# Altium schematic sheet Sheet4.SchDoc(Sheet4)
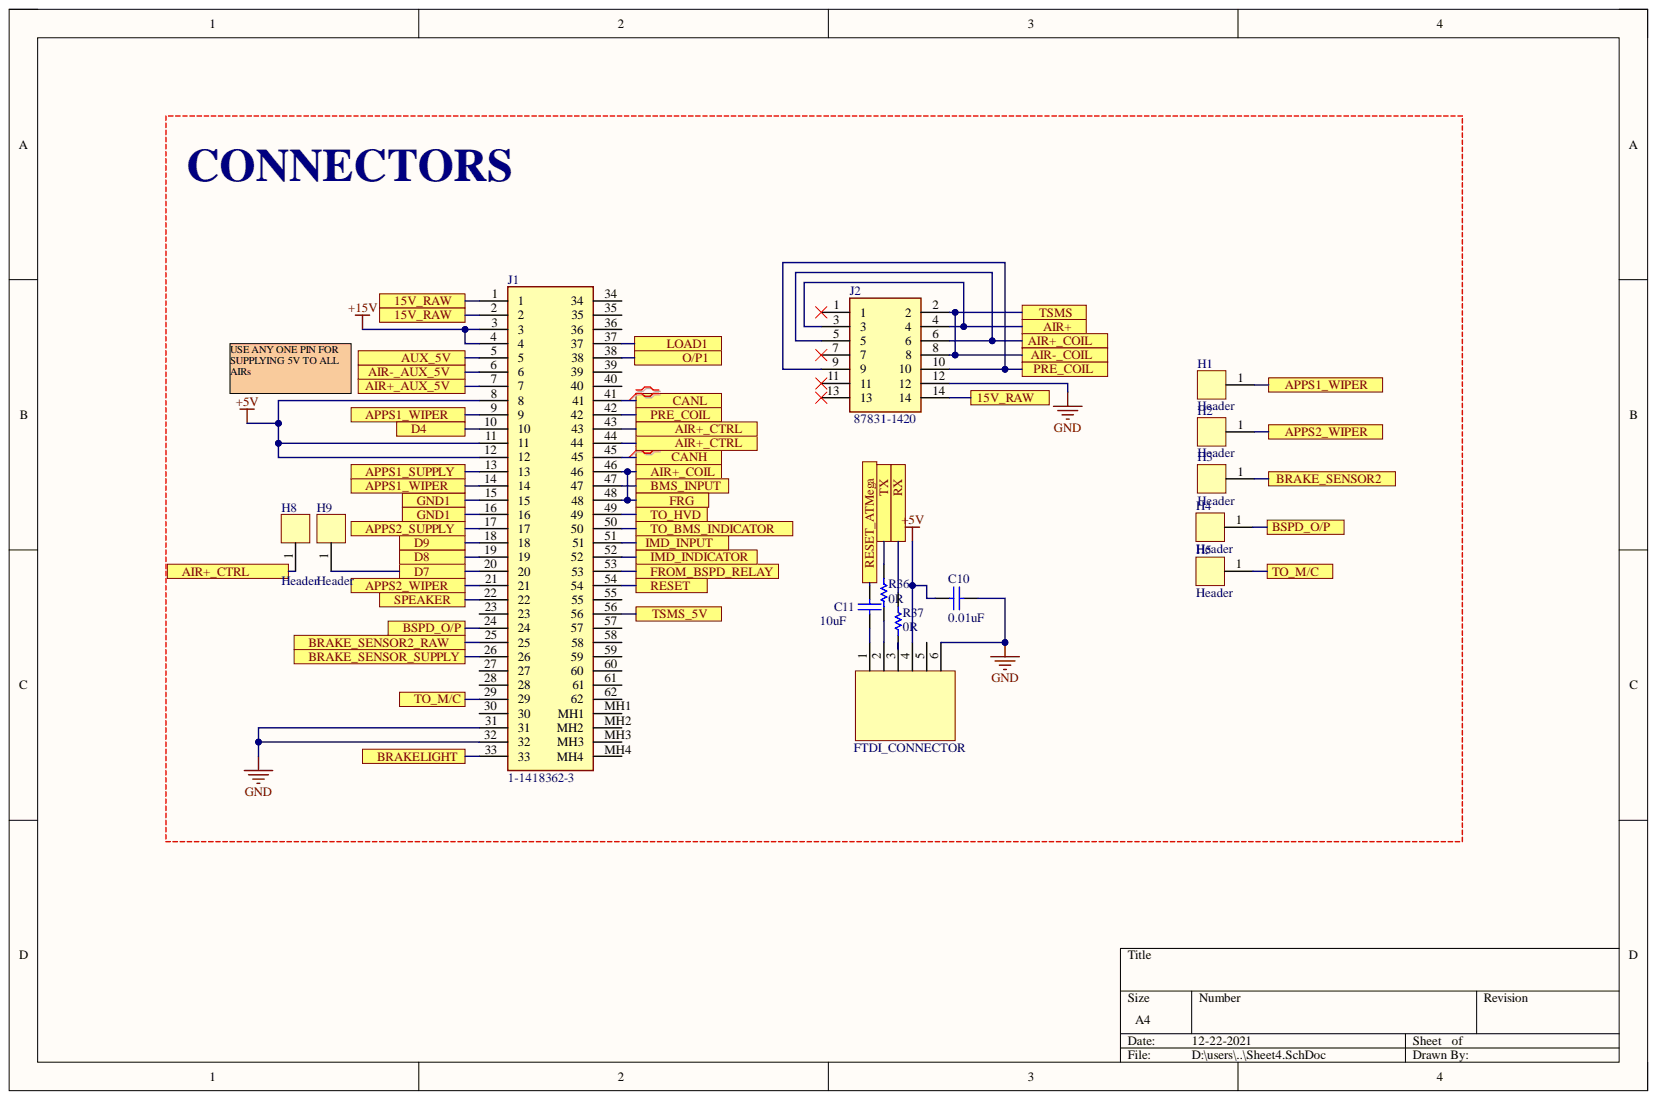
[diagram p0: Sheet4.SchDoc]

## Parts
2  FTDI_connector  [ARDUINO_MEGA_NO_SILK]  (value not drawn)
C10  0.01uF  [KEMT-1206-2_N]
C11  10uF  [KEMT-1206-2_N]
H1  Header  [Header]
H2  Header  [Header]
H3  Header  [Header]
H4  Header  [Header]
H5  Header  [Header]
H8  Header  [Header]
H9  Header  [Header]
J1  1-1418362-3  [114183623]
J2  87831-1420  [SHDR14W55P200_2X7_1665X630X640P]
R36  0R  [R1206]
R37  0R  [R1206]

## Ports
15V_RAW, AIR+, AIR+_AUX_5V, AIR+_COIL, AIR+_CTRL, AIR-_AUX_5V, AIR-_COIL, APPS1_SUPPLY, APPS1_WIPER, APPS2_SUPPLY, APPS2_WIPER, AUX_5V, BMS_INPUT, BRAKE_SENSOR2, BRAKE_SENSOR2_RAW, BRAKE_SENSOR_SUPPLY, BRAKELIGHT, BSPD_O/P, CANH, CANL, D4, D7, D8, D9, FRG, FROM_BSPD_RELAY, GND1, IMD_INDICATOR, IMD_INPUT, LOAD1, O/P1, PRE_COIL, RESET, RESET_ATMega, RX, SPEAKER, TO_BMS_INDICATOR, TO_HVD, TO_M/C, TSMS, TSMS_5V, TX

## Nets
+5V: 2.4 C10.2 J1.8 J1.11 J1.12
+15V: J1.3 J1.4
GND: 2.6 C10.1 J1.31 J1.32 J2.12
15V_RAW: J1.1 J1.2 J2.14 ; port 15V_RAW
AIR+: J2.3 J2.4 ; port AIR+
AIR+_CTRL: H8.1 J1.43 J1.44 ; port AIR+_CTRL
APPS1_SUPPLY: J1.13 ; port APPS1_SUPPLY
APPS1_WIPER: H1.1 J1.9 J1.14 ; port APPS1_WIPER
APPS2_SUPPLY: J1.17 ; port APPS2_SUPPLY
APPS2_WIPER: H2.1 J1.21 ; port APPS2_WIPER
AUX_5V: J1.5 ; port AUX_5V
BMS_INPUT: J1.47 ; port BMS_INPUT
BRAKE_SENSOR2: H3.1 ; port BRAKE_SENSOR2
BRAKE_SENSOR2_RAW: J1.25 ; port BRAKE_SENSOR2_RAW
BRAKE_SENSOR_SUPPLY: J1.26 ; port BRAKE_SENSOR_SUPPLY
BRAKELIGHT: J1.33 ; port BRAKELIGHT
BSPD_O/P: H4.1 J1.24 ; port BSPD_O/P
CANH: J1.45 ; port CANH
CANL: J1.41 ; port CANL
D4: J1.10 ; port D4
D7: H9.1 J1.20 ; port D7
D8: J1.19 ; port D8
D9: J1.18 ; port D9
FRG: J1.46 J1.48 J2.5 J2.6 ; port FRG
FROM_BSPD_RELAY: J1.53 ; port FROM_BSPD_RELAY
GND1: J1.15 J1.16 ; port GND1
IMD_INDICATOR: J1.52 ; port IMD_INDICATOR
IMD_INPUT: J1.51 ; port IMD_INPUT
LOAD1: J1.37 ; port LOAD1
O/P1: J1.38 ; port O/P1
PRE_COIL: J1.42 J2.9 J2.10 ; port PRE_COIL
RESET: J1.54 ; port RESET
RESET_ATMega: C11.2 ; port RESET_ATMega
RX: R37.1 ; port RX
SPEAKER: J1.22 ; port SPEAKER
TO_BMS_INDICATOR: J1.50 ; port TO_BMS_INDICATOR
TO_HVD: J1.49 ; port TO_HVD
TO_M/C: H5.1 J1.29 ; port TO_M/C
TSMS: J2.2 J2.8 ; port TSMS
TSMS_5V: J1.56 ; port TSMS_5V
TX: R36.1 ; port TX
Net2_1: 2.1 C11.1
Net2_2: 2.2 R36.2
Net2_3: 2.3 R37.2
NetJ1_6: J1.6
NetJ1_7: J1.7

Not connected: 2 5; J1 23 27 28 30 34 35 36 39 40 55 57 58 59 60 61 62 MH1 MH2 MH3 MH4; J2 1 7 11 13

## Library data of the parts
C10 C11 = CMP-1037-04202-2: CAP, Ceramic, 0.1 uF, +/- 10%, 50 V, -55 to 125 degC, 1206 (3216 Metric), RoHS, Tape and Reel; Manufacturer: Kemet; Capacitance: 0.1µF; Capacitor Case Style: 1206[3216Metric]; Dielectric Characteristic: X7R; Product Range: KEMET-CSeries; SVHC: ToBeAdvised; Voltage Rating: 50V; Capacitance Tolerance: ±10%; Component Kind: Standard; Datasheet URL: https://datasheet.ciiva.com/6125/1725438-6125494.pdf; Datasheet Version: Jul-13; Manufacturer URL: http://www.kemet.com/; Operating Temperature Max: 125degC; Operating Temperature Min: -55degC; Package Description: 2-Leads SMD, Body 3.2 x 1.6 mm; Package Reference: 1206-2; Packaging: Tape&Reel; Library Name: Schlib1_TSAL_LV_3.1.SchLib
J1 = 1-1418362-3: Connector; Manufacturer_Name: TE Connectivity; Arrow Part Number: 1-1418362-3; Arrow Price/Stock: https://www.arrow.com/en/products/1-1418362-3/te-connectivity; Component Kind: Standard; Datasheet Link: https://www.arrow.com/en/products/1-1418362-3/te-connectivity; Height: 48mm; Library Name: SamacSys.SchLib; Manufacturer_Part_Number: 1-1418362-3; Mouser Part Number: 571-1-1418362-3; Mouser Price/Stock: https://www.mouser.co.uk/ProductDetail/TE-Connectivity-AMP/1-1418362-3?qs=J7fCQMU5Gej7aVw8AejcvQ%3D%3D
J2 = 87831-1420: Connector; Manufacturer_Name: Molex; Component Kind: Standard; Datasheet Link: http://docs-emea.rs-online.com/webdocs/150d/0900766b8150deae.pdf; Height: 6.4mm; Library Name: SamacSys.SchLib; Manufacturer_Part_Number: 87831-1420; Mouser Part Number: 538-87831-1420; Mouser Price/Stock: https://www.mouser.co.uk/ProductDetail/Molex/87831-1420/?qs=QtQX4uD3c2VDCL534TqpVg%3D%3D
R36 R37 = RES: Manufacturer: Vishay Dale; Part Number: CRCW120647K0FKEA; Component Kind: Standard; Library Name: Schlib1.SchLib
2 = FTDI_connector: Component Kind: Standard; Library Name: Schlib1.SchLib
H1-H5 H8 H9 = Header: Component Kind: Standard; Library Name: Schlib1.SchLib
